# Altium schematic sheet Interface.SchDoc(Interface)
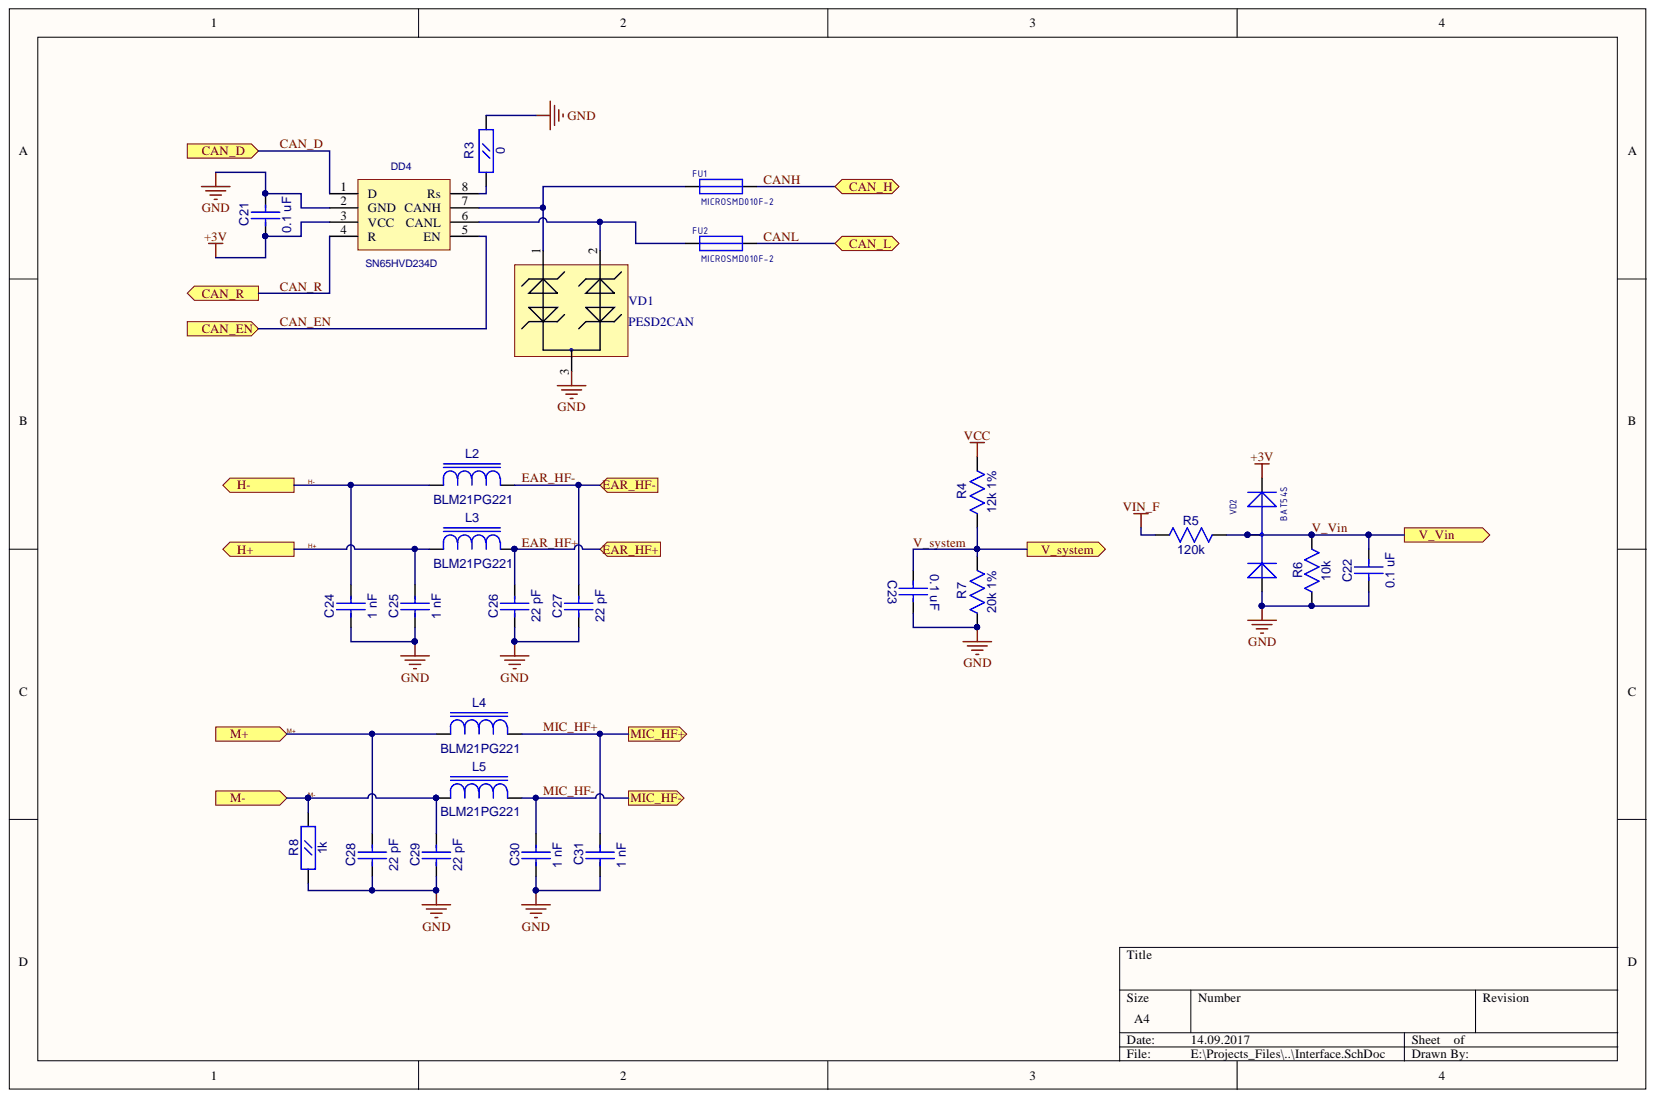
[diagram p0: Interface.SchDoc]

## Parts
C21  0.1 uF  [CC0603]
C22  0.1 uF  [CC0603-3M]
C23  0.1 uF  [CC0603-3M]
C24  1 nF  [CC0603]
C25  1 nF  [CC0603]
C26  22 pF  [CC0603]
C27  22 pF  [CC0603]
C28  22 pF  [CC0603]
C29  22 pF  [CC0603]
C30  1 nF  [CC0603]
C31  1 nF  [CC0603]
DD4  SN65HVD234D  [SO-8]
FU1  MICROSMD010F-2  [RC1210]
FU2  MICROSMD010F-2  [RC1210]
L2  BLM21PG221  [RC0805]
L3  BLM21PG221  [RC0805]
L4  BLM21PG221  [RC0805]
L5  BLM21PG221  [RC0805]
R3  0  [RC0603]
R4  12k 1%  [RC0603-3M]
R5  120k  [RC0603-3M]
R6  10k  [RC0603-3M]
R7  20k 1%  [RC0603-3M]
R8  1k  [RC0603]
VD1  PESD2CAN  [SOT-23(123)]
VD2  BAT54S  [SOT-23(GSD)]

## Ports
CAN_D, CAN_EN, CAN_H, CAN_L, CAN_R, EAR_HF+, EAR_HF-, H+, H-, M+, M-, MIC_HF+, MIC_HF-, V_system, V_Vin

## Nets
+3V: C21.1 DD4.3(VCC) VD2.2
GND: C21.2 C22.2 C23.2 C24.1 C25.1 C26.1 C27.1 C28.1 C29.1 C30.1 C31.1 DD4.2(GND) R3.2 R6.2 R7.1 R8.1 VD1.3 VD2.1
VCC: R4.2
VIN_F: R5.2
CAN_D: DD4.1(D) ; port CAN_D
CAN_EN: DD4.5(EN) ; port CAN_EN
CAN_R: DD4.4(R) ; port CAN_R
CANH: FU1.2 ; port CAN_H
CANL: FU2.2 ; port CAN_L
EAR_HF+: C26.2 L3.1 ; port EAR_HF+
EAR_HF-: C27.2 L2.1 ; port EAR_HF-
H+: C25.2 L3.2 ; port H+
H-: C24.2 L2.2 ; port H-
M+: C28.2 L4.1 ; port M+
M-: C29.2 L5.1 R8.2 ; port M-
MIC_HF+: C31.2 L4.2 ; port MIC_HF+
MIC_HF-: C30.2 L5.2 ; port MIC_HF-
V_system: C23.1 R4.1 R7.2 ; port V_system
V_Vin: C22.1 R5.1 R6.1 VD2.3 ; port V_Vin
NetDD4_6: DD4.6(CANL) FU2.1 VD1.2
NetDD4_7: DD4.7(CANH) FU1.1 VD1.1
NetDD4_8: DD4.8(Rs) R3.1

## Library data of the parts
C21-C31 = CC0603: Description: CC0603; Component Kind: Standard
  C21-C23: Value: 0.1 uF
  C24 C25 C30 C31: Value: 1 nF
  C26-C29: Value: 22 pF
  C22 C23: Library Name: gprs_modem.SchLib
DD4 = SN65HVD234D: CAN Transceiver; Component Kind: Standard; Library Name: gprs_modem.SchLib
FU1 FU2 = MICROSMD: Value: MICROSMD010F-2; Description: MICROSMD; Component Kind: Standard; Library Name: gprs_modem_2.SchLib
R3-R8 = RC0603: Description: RC0603; Component Kind: Standard
  R3: Value: 0
  R4: Value: 12k 1%
  R5: Value: 120k
  R6: Value: 10k
  R7: Value: 20k 1%
  R8: Value: 1k
VD1 = PESD2CAN: TVS for CAN; Component Kind: Standard; Library Name: gprs_modem.SchLib
VD2 = BAT54S: 1.0 Ampere General Purpose Rectifier; ComponentField6: Published 8-Jun-2000, Modified 11-July-2000: SIM Model Added.; Simulation: DIODE; Component Kind: Standard; Library Name: gprs_modem.SchLib
L2-L5 = BLM21PG221: Description: BLM21PG221; Component Kind: Standard
